# Altium schematic sheet OpenBLC_LTC4412.SchDoc(OpenBLC_LTC4412)
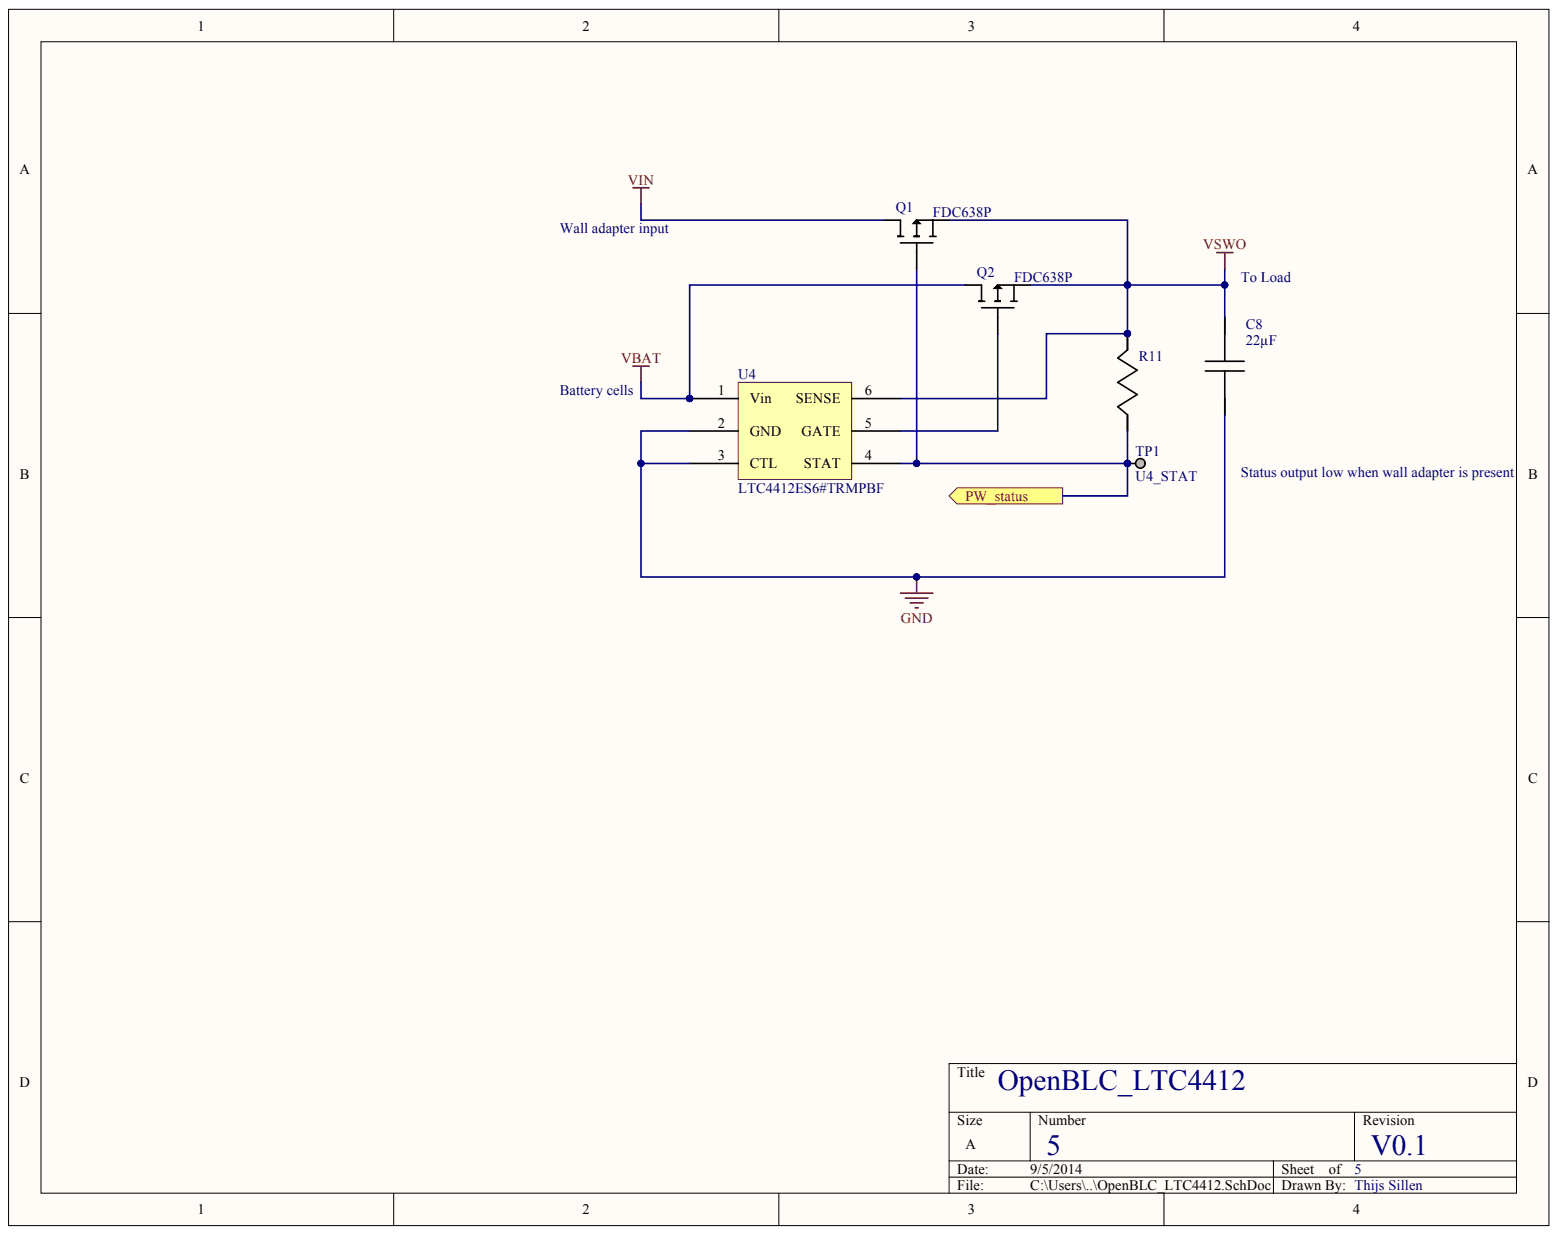
[diagram p0: OpenBLC_LTC4412.SchDoc]

## Parts
C8  Capacitance: 22µF  [0805_CAP]  (hidden comment: C2012X5R0J226M125AC)
Q1  FDC638P  [FDC638P]
Q2  FDC638P  [FDC638P]
R11  0805_RES  [0805_RES]  (value not drawn)
TP1  U4_STAT  [Via_TP]
U4  LTC4412ES6#TRMPBF  [SOT95P280X110-6N]

## Ports
PW_STATUS

## Nets
GND: C8.2 U4.2(GND) U4.3(CTL)
VBAT: Q2.1 U4.1(Vin)
VIN: Q1.1
VSWO: C8.1 Q1.3 Q2.3 R11.1 U4.6(SENSE)
NetQ1_2: Q1.2 R11.2 TP1.1 U4.4(STAT) ; port PW_STATUS
NetQ2_2: Q2.2 U4.5(GATE)

## Library data of the parts
C8 = C2012X5R0J226M125AC: CAP CER 22UF 6.3V 20% X5R 0805; Manufacturer: TDK Corporation; Applications: General Purpose; Category: Capacitors; Component Kind: Standard; ComponentLink1Description: http://product.tdk.com/en/catalog/datasheets/mlcc_commercial_general_en.pdf; ComponentLink1URL: http://product.tdk.com/en/catalog/datasheets/mlcc_commercial_general_en.pdf; Features: -; Height - Seated (Max): -; Lead Spacing: -; Lead Style: -; Library Name: Schlib1.SchLib; Manufacturer Part Number: C2012X5R0J226M125AC; Mounting Type: Surface Mount, MLCC; Operating Temperature: -55°C ~ 85°C; Package / Case: 0805 (2012 Metric); Packaging: Cut Tape (CT); Pricing 1: 1=0.24, 10=0.204, 100=0.1021, 250=0.07656, 500=0.06762, 1000=0.05878 (EUR); Ratings: -; RoHS: RoHS Compliant; Size / Dimension: 0.079" L x 0.049" W (2.00mm x 1.25mm); Stock 1: 147939; Supplier 1: Digi-Key; Supplier Part Number 1: 445-1422-1-ND; Temperature Coefficient: X5R; Thickness (Max): 0.057" (1.45mm); Tolerance: ±20%; Voltage - Rated: 6.3V
Q1 Q2 = FDC638P: MOSFET SSOT-6 P-CH -20V; Configuratie: Single Quad Drain; Daaltijd: 9 ns; Fabrikant: Fairchild Semiconductor; Id - Continue afvoerstroom: 4.5 A; Kanaalmodus: Enhancement; Maximale bedrijfstemperatuur: + 150 C; Minimale bedrijfstemperatuur: - 55 C; Montagetype: SMD/SMT; Pd - Vermogensverlies: 1.6 W; Polariteit transistor: P-Channel; Product Status: New Product; Rds On - Druppelbronweerstand: 48 mOhms; Standaard pakket hoeveelheid: 3000; Typische uitschakelvertragingstijd: 33 ns; Verpakken: Reel; Vgs - Doorslagspanning van ingangsbron: 12 V; Voorwaartse transconductantie - min: 15 S; Category: MOSFET; Component Kind: Standard; ComponentLink1Description: http://www.mouser.com/ds/2/149/FDC638P-103274.pdf; ComponentLink1URL: http://www.mouser.com/ds/2/149/FDC638P-103274.pdf; Library Name: Schlib1.SchLib; Manufacturer: Fairchild Semiconductor; Manufacturer Part Number: FDC638P; Merk: Fairchild Semiconductor; Minimum Order Quantity: 1; Pricing 1: 1=0.400, 10=0.272, 100=0.180, 1000=0.139, 3000=0.117, 9000=0.113, 24000=0.107, 45000=0.105 (EUR); Reeks: FDC638P; Reeling: True; RoHS: RoHS Compliant; RoHS - Mouser: Y; Stijgtijd: 9 ns; Stock 1: 2304; Supplier 1: Mouser; Supplier Part Number 1: 512-FDC638P; Vds - Doorslagspanning van druppelbron: - 20 V; Verpakking / doos: SSOT-6
TP1 = TESTPOINT: TESTPOINT SCOPE GRABBER; Manufacturer: Keystone Electronics; Manufacturer Part Number: 5010; Reel: TH; Component Kind: Standard; Library Name: vh_altium_library.intLib; Supplier 1: Digi-Key; Supplier Part Number 1: 5010K-ND
U4 = LTC4412ES6#TRMPBF: IC OR CTRLR SRC SELECT TSOT23-6; Manufacturer: Linear Technology; Current - Supply: 15µA; Delay Time - OFF: 13µs; Delay Time - ON: 110µs; FET Type: P-Channel; Internal Switch(s): No; Ratio - Input: 2:1; Voltage - Supply: 2.5 V ~ 28 V; Applications: Handheld/Mobile Devices; Category: Integrated Circuits (ICs); Component Kind: Standard; ComponentLink1Description: http://www.linear.com/docs/1527; ComponentLink1URL: http://www.linear.com/docs/1527; Current - Output (Max): -; Library Name: Schlib1.SchLib; Manufacturer Part Number: LTC4412ES6#TRMPBF; Mounting Type: Surface Mount; Operating Temperature: -40°C ~ 85°C; Package / Case: SOT-23-6 Thin, TSOT-23-6; Packaging: Cut Tape (CT); Pricing 1: 1=2.79, 25=2.4972, 100=2.2477 (EUR); RoHS: RoHS Compliant; Stock 1: 19945; Supplier 1: Digi-Key; Supplier Device Package: TSOT-23-6; Supplier Part Number 1: LTC4412ES6#TRMPBFCT-ND; Type: Source Selector Switch
R11 = 0805_RES: Component Kind: Standard; Library Name: Schlib1.SchLib
